# Altium schematic sheet HAL_4.SchDoc
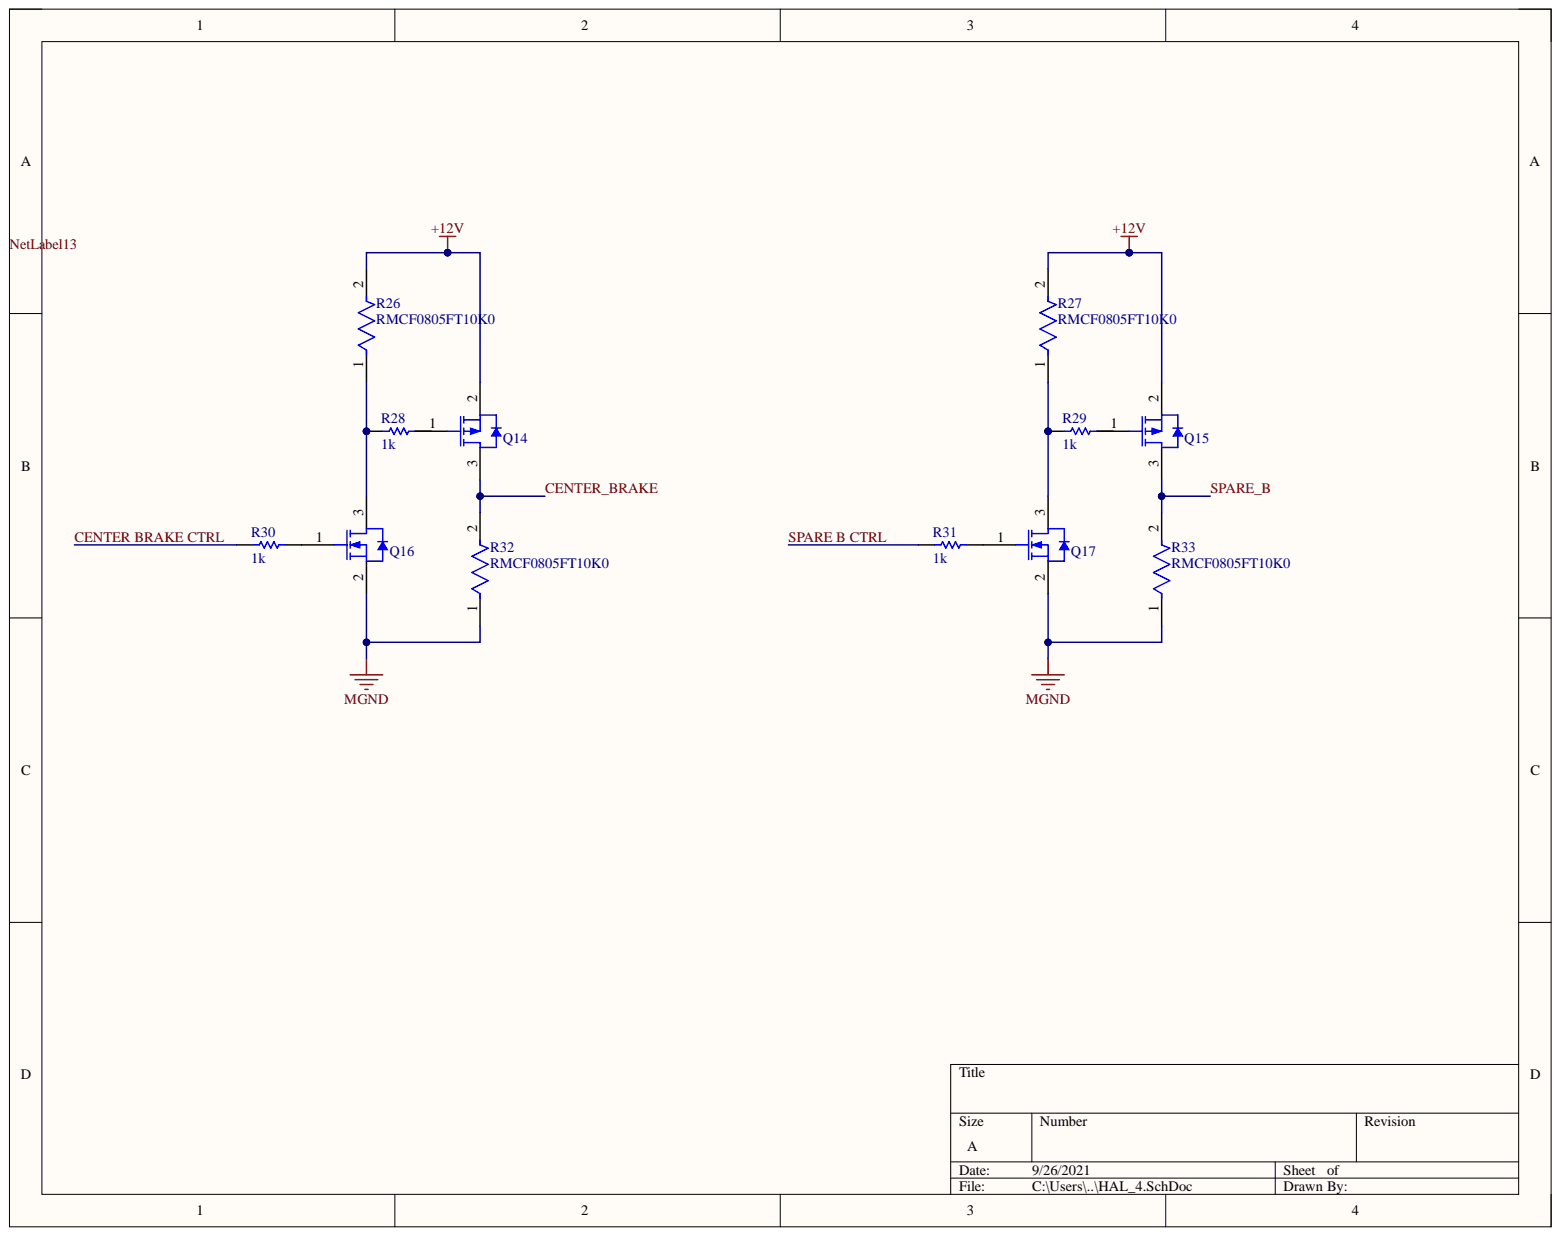
[diagram p0: HAL_4.SchDoc]

## Parts
Q14  IRLML6402TRPBF  [INTR-SOT-23-3_V]  (value not drawn)
Q15  IRLML6402TRPBF  [INTR-SOT-23-3_V]  (value not drawn)
Q16  NTR4501NT1G  [ONSC-SOT-23-3-318-08_V]  (value not drawn)
Q17  NTR4501NT1G  [ONSC-SOT-23-3-318-08_V]  (value not drawn)
R26  RMCF0805FT10K0  [FP-RMCF0805-MFG]
R27  RMCF0805FT10K0  [FP-RMCF0805-MFG]
R28  1k  [RESC3216X70X40LL20T20]
R29  1k  [RESC3216X70X40LL20T20]
R30  1k  [RESC3216X70X40LL20T20]
R31  1k  [RESC3216X70X40LL20T20]
R32  RMCF0805FT10K0  [FP-RMCF0805-MFG]
R33  RMCF0805FT10K0  [FP-RMCF0805-MFG]

## Nets
+12V: Q14.2 Q15.2 R26.2 R27.2
MGND: Q16.2 Q17.2 R32.1 R33.1
CENTER BRAKE CTRL: R30.1
CENTER_BRAKE: Q14.3 R32.2
SPARE B CTRL: R31.1
SPARE_B: Q15.3 R33.2
NetQ16_1: Q16.1 R30.2
NetQ16_3: Q16.3 R26.1 R28.1
NetQ17_1: Q17.1 R31.2
NetQ17_3: Q17.3 R27.1 R29.1
NetLabel13:

Not connected: Q14 1; Q15 1; R28 2; R29 2

## Library data of the parts
Q14 Q15 = CMP-2000-04987-1: HEXFET(R) Power P-Channel MOSFET, -20 V, -3.7 A, -55 to 150 degC, 3-Pin SOT-23, RoHS, Tape and Reel; Manufacturer: International Rectifier; Drain-Source On Resistance-Max: 0.065O; Drain-to-Source Voltage [Vdss]: 20V; Qg Gate Charge: 8nC; Rated Power Dissipation: 1.3W; Channel Type: P-Channel; Component Kind: Standard; Datasheet URL: http://datasheet.octopart.com/IRLML6402TRPBF-International-Rectifier-datasheet-93882.pdf; Datasheet Version: Feb-05; Library Name: Altium Content Vault; Manufacturer URL: http://internationalrectifier.com/; Package Description: 3-Pin Small Outline Transistor, Body 2.92 x 1.3 mm, Pitch 0.95 mm; Package Reference: SOT-23-3
Q16 Q17 = CMP-1058-00732-1: Power MOSFET, 20 V, 3.2 A, Single N-Channel, 3-Pin SOT-23, Pb-Free, Tape and Reel; Manufacturer: On Semiconductor; Channel Polarity: N Channel; Ciss Typ (pF): 200; Coss Typ (pF): 80; Crss Typ (pF): 50; PD Max (W): 1.25; Qrr Typ (nC): 3; V(BR)DSS Min (V): 20; VGS Max (V): 12; VGS(th) Max (V): 1.2; Component Kind: Standard; ComponentLink1Description: Manufacturer URL; ComponentLink1URL: http://www.onsemi.com/; ComponentLink2Description: Datasheet; ComponentLink2URL: http://www.onsemi.cn/pub_link/Collateral/NTR4501N-D.PDF; ComponentLink3Description: Package Specification; ComponentLink3URL: http://www.onsemi.cn/pub_link/Collateral/318-08.PDF; Configuration: Single; DatasheetVersion: Rev. 13; Library Name: Altium Content Vault; Mounting Technology: Surface Mount; Package Type: SOT-23-3; PackageDescription: 3-Pin Small Outline Transistor, Body 2.92 x 1.3 mm, Pitch 0.95 mm; PackageReference: SOT-23-3-318-08; PackageVersion: Rev. AP, 11/2009; Packing: Tape and Reel; PartNumber: NTR4501NT1G; RoHS: Pb-Free
R26 R27 R32 R33 = CMP-26527-000121-1: RES 10K OHM 1% 1/8W 0805; Max Power Dissipation: 125mW; Resistance: 10kR; Temperature Coefficient: 100ppm/°C; Case Code (Imperial): 0805; Case Code (Metric): 2012; Case/Package: 0805; Component Kind: Standard; Construction: Rectangular; Contact Plating: Tin; Depth: 1.25mm; Features: Automotive AEC-Q200; Height: 0.026inch; Lead Free: Lead Free; Length: 2mm; Library Name: Altium Content Vault; Max Operating Temperature: 155°C; Military Standard: Not; Min Operating Temperature: -55°C; Mount: Surface Mount; Number of Terminations: 2; Packaging: Tape and Reel; Power Rating: 125mW; Radiation Hardening: No; Resistor Type: Thick Film; RoHS Compliant: Yes; Series: RMCF; Size Code: 0805; Tolerance: 1%; Width: 0.049inch
R28-R31 = CMP-2003-04517-1: RK73B2BTTD102J; Manufacturer: KOA Speer; Component Kind: Standard; Library Name: Altium Content Vault; Part Number: RK73B2BTTD102J
